# Altium schematic sheet GasCap.I2CSensors.SchDoc
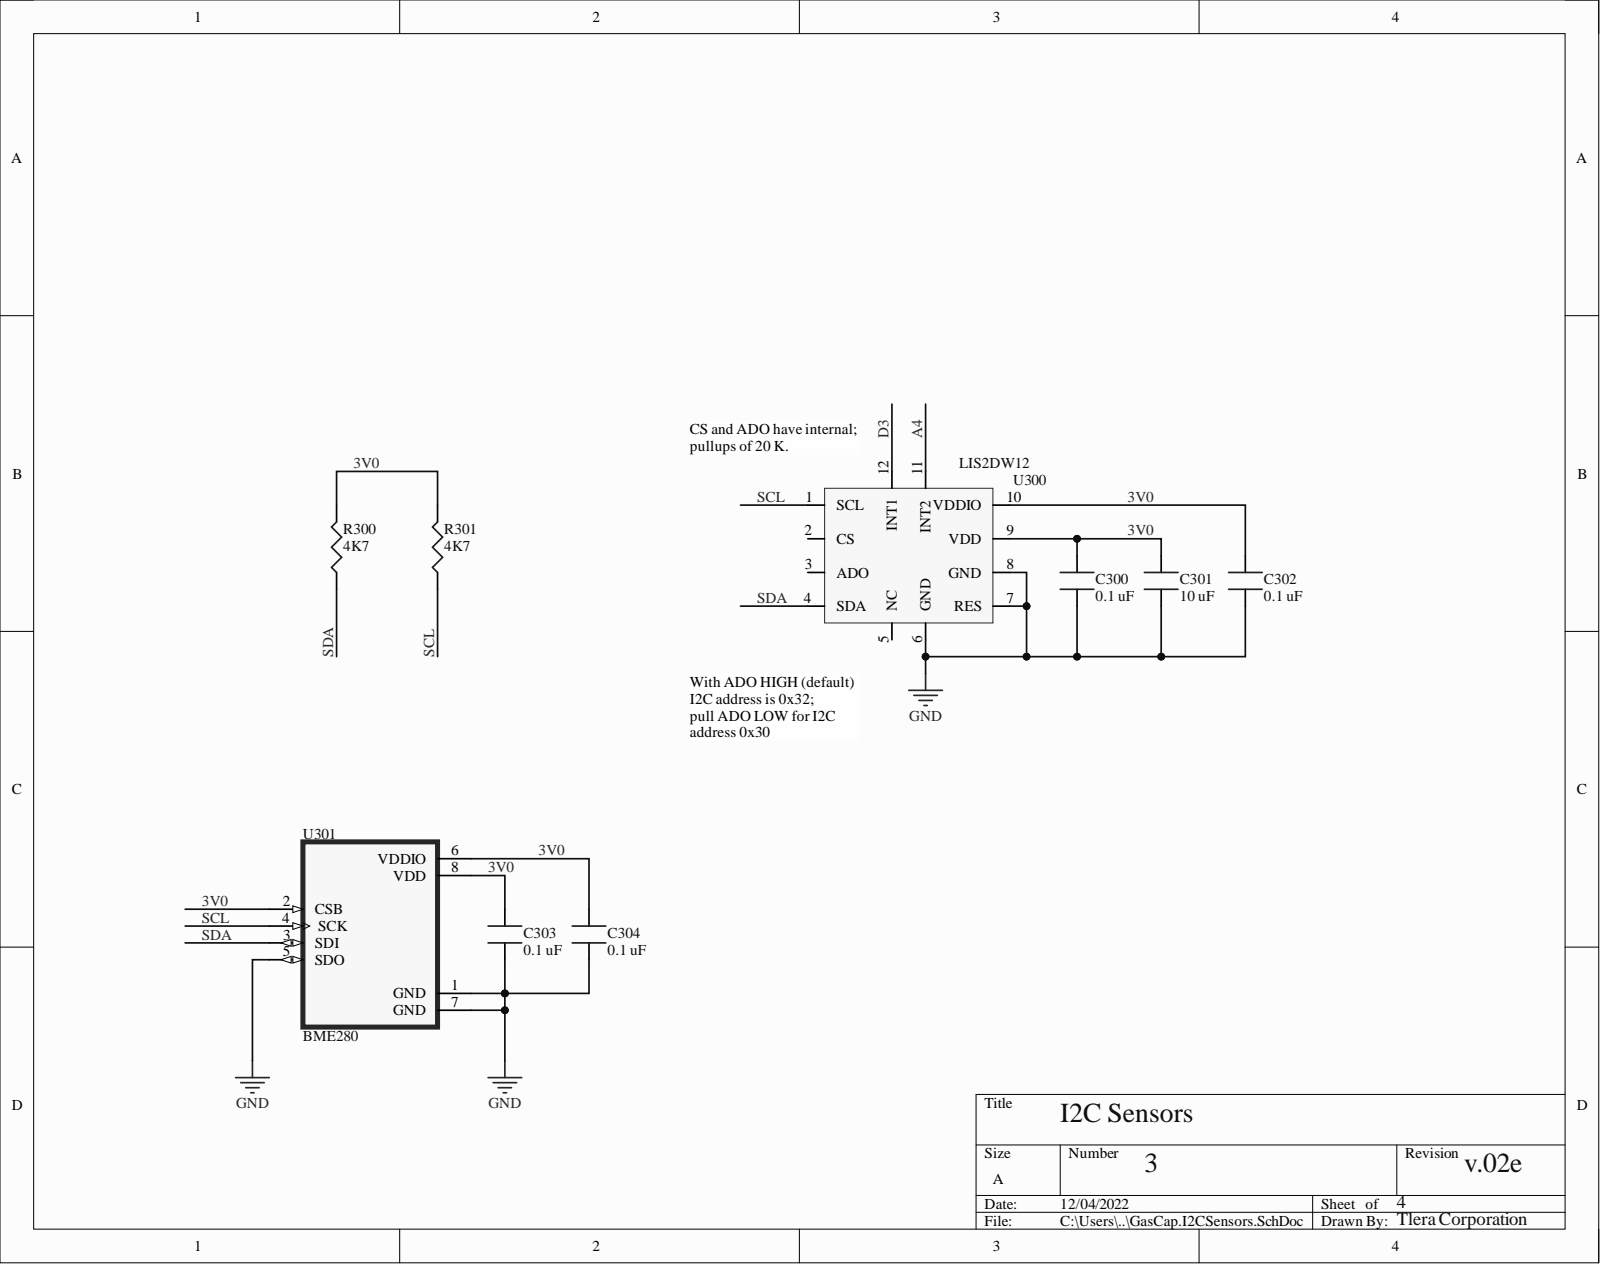
[diagram p0: GasCap.I2CSensors.SchDoc]

## Parts
C300  0.1 uF  [402Cap]
C301  10 uF  [402Cap]
C302  0.1 uF  [402Cap]
C303  0.1 uF  [402Cap]
C304  0.1 uF  [402Cap]
R300  4K7  [402RES]
R301  4K7  [402RES]
U300  LIS2DW12  [LIS2DW12]
U301  BME280  [PSON65P250X250X100-8N]

## Nets
GND: C300.1 C301.1 C302.1 C303.1 C304.1 U300.6(GND) U300.7(RES) U300.8(GND) U301.1(GND) U301.5(SDO) U301.7(GND)
3V0: C300.2 C301.2 C302.2 C303.2 C304.2 R300.2 R301.2 U300.9(VDD) U300.10(VDDIO) U301.2(CSB) U301.6(VDDIO) U301.8(VDD)
A4: U300.11(INT2)
D3: U300.12(INT1)
SCL: R301.1 U300.1(SCL) U301.4(SCK)
SDA: R300.1 U300.4(SDA) U301.3(SDI)

Not connected: U300 2(CS) 3(ADO) 5(NC)

## Library data of the parts
C300 C302-C304 = CL05B104KO5NNNC: 0402 0.1 uF Ceramic Capacitor, Multilayer, Ceramic, 16V, 10% +Tol, 10% -Tol, X7R, 15% TC, 0.1uF, Surface Mount, 0402; Manufacturer: Samsung; Capacitance: 100nF; Multilayer: Yes; Capacitor Type: Ceramic; Category: Ceramic Capacitors; Component Kind: Standard; ComponentLink1Description: https://datasheet.ciiva.com:443/pdfs/VipMasterIC/IC/SEMS/SEMS-S-A0008384515/SEMS-S-A0008872921-1.pdf?src-supplier=IHS+Markit; ComponentLink1URL: https://datasheet.ciiva.com:443/pdfs/VipMasterIC/IC/SEMS/SEMS-S-A0008384515/SEMS-S-A0008872921-1.pdf?src-supplier=IHS+Markit; ComponentLink2Description: https://datasheet.ciiva.com/26920/getdatasheetpartid-359683-26920169.pdf?src-supplier=Verical; ComponentLink2URL: https://datasheet.ciiva.com/26920/getdatasheetpartid-359683-26920169.pdf?src-supplier=Verical; ComponentLink3Description: https://datasheet.ciiva.com/29198/458718-da-01-en-vs-kondensator-0402-29198423.pdf?src-supplier=Conrad; ComponentLink3URL: https://datasheet.ciiva.com/29198/458718-da-01-en-vs-kondensator-0402-29198423.pdf?src-supplier=Conrad; ComponentLink4Description: https://datasheet.ciiva.com/16208/cl05b104kq5nnnc-16208343.pdf?src-supplier=Future+Electronics; ComponentLink4URL: https://datasheet.ciiva.com/16208/cl05b104kq5nnnc-16208343.pdf?src-supplier=Future+Electronics; ComponentLink5Description: https://datasheet.ciiva.com/26309/mlcc-catalogdownload2013-eng-26309003.pdf?src-supplier=Distrelec; ComponentLink5URL: https://datasheet.ciiva.com/26309/mlcc-catalogdownload2013-eng-26309003.pdf?src-supplier=Distrelec; Dielectric Material: Ceramic; Height: 0.55mm; JESD-609 Code: e3; Length: 1mm; Manufacturer Part Number: CL05B104KO5NNNC; Max Operating Temperature: 125°C; Min Operating Temperature: -55°C; Mount: Surface Mount; Negative Tolerance: 10%; Number of Pins: 2; Package Shape: Rectangular; Package Style: SMTMeter; Packaging: Tape and Reel; Positive Tolerance: 10%; Pricing 1: 1=0.10000, 10=0.02600, 50=0.01400, 100=0.01150, 500=0.00820, 1000=0.00644, 2500=0.00586, 5000=0.00539 (USD); Rated DC Voltage (URdc): 16V; REACH SVHC: Yes; RoHS: Yes; RoHS Compliant: Yes; Size Code: 0402; Stock 1: 30141598; Supplier 1: Digi-Key; Supplier Part Number 1: 1276-1001-1-ND; Temperature Characteristics Code: X7R; Terminal Finish: Nickel; Termination: Wraparound; Width: 0.5mm
  C300 C302: Library Name: Gnat.SchLib
  C303 C304: Library Name: GasCap.SchLib
C301 = CL05A106MQ5NUNC: 0402 10uF Ceramic Capacitor, Multilayer, Ceramic, 6.3V, 20% +Tol, 20% -Tol, X5R, 15% TC, 10uF, Surface Mount, 0402; Manufacturer: Samsung; Multilayer: Yes; Capacitance: 10uF; Capacitor Type: Ceramic; Category: Ceramic Capacitors; Component Kind: Standard; ComponentLink1Description: https://datasheet.ciiva.com:443/pdfs/VipMasterIC/IC/SEMS/SEMS-S-A0008521219/SEMS-S-A0008521300-1.pdf?src-supplier=IHS+Markit; ComponentLink1URL: https://datasheet.ciiva.com:443/pdfs/VipMasterIC/IC/SEMS/SEMS-S-A0008521219/SEMS-S-A0008521300-1.pdf?src-supplier=IHS+Markit; ComponentLink2Description: https://datasheet.ciiva.com/16437/cl05a106mq5nunc-16437356.pdf?src-supplier=Future+Electronics; ComponentLink2URL: https://datasheet.ciiva.com/16437/cl05a106mq5nunc-16437356.pdf?src-supplier=Future+Electronics; ComponentLink3Description: https://datasheet.ciiva.com/26877/getdatasheetpartid-355289-26877841.pdf?src-supplier=Verical; ComponentLink3URL: https://datasheet.ciiva.com/26877/getdatasheetpartid-355289-26877841.pdf?src-supplier=Verical; Dielectric Material: Ceramic; Height: 0.7mm; JESD-609 Code: e3; Length: 1mm; Manufacturer Part Number: CL05A106MQ5NUNC; Max Operating Temperature: 85°C; Min Operating Temperature: -55°C; Mount: Surface Mount; Negative Tolerance: 20%; Number of Pins: 2; Package Shape: Rectangular; Package Style: SMTMeter; Packaging: Tape and Reel; Positive Tolerance: 20%; Pricing 1: 1=0.26000, 10=0.17600, 50=0.10540, 100=0.08900, 500=0.06086, 1000=0.05384, 2500=0.05150, 5000=0.04682 (USD); Rated DC Voltage (URdc): 6.3V; REACH SVHC: Yes; RoHS: Yes; RoHS Compliant: Yes; Size Code: 0402; Stock 1: 9272723; Supplier 1: Digi-Key; Supplier Part Number 1: 1276-1451-1-ND; Temperature Characteristics Code: X5R; Terminal Finish: Nickel; Termination: Wraparound; Width: 0.5mm; Library Name: Gnat.SchLib
R300 R301 = RC0402FR-074K7P: 0402 4K7 Fixed Resistor, Metal Glaze/thick Film, 0.0625W, 4700ohm, 50V, 1% +/-Tol, 150ppm/Cel, Surface Mount, 0402; Manufacturer: Yageo; Additional Feature: LASER TRIMMABLE; Rated Power Dissipation (P): 62.5mW; Rated Temperature: 70°C; Resistance: 4.7kR; Temperature Coefficient: 150ppm/°C; Category: Chip SMD Resistors; Component Kind: Standard; ComponentLink1Description: https://datasheet.ciiva.com:443/pdfs/VipMasterIC/IC/YAGO/YAGO-S-A0006248068/YAGO-S-A0006248068-1.pdf?src-supplier=IHS+Markit; ComponentLink1URL: https://datasheet.ciiva.com:443/pdfs/VipMasterIC/IC/YAGO/YAGO-S-A0006248068/YAGO-S-A0006248068-1.pdf?src-supplier=IHS+Markit; ComponentLink2Description: http://www.yageo.com.tw/exep/pages/download/literatures/PYu-R_INT-thick_7.pdf?src-supplier=Digi-Key; ComponentLink2URL: http://www.yageo.com.tw/exep/pages/download/literatures/PYu-R_INT-thick_7.pdf?src-supplier=Digi-Key; ComponentLink3Description: http://www.yageo.com/NewPortal/yageodocoutput?fileName=/pdf/R-Chip/PYu-RC_51_RoHS_P_0.pdf&src-supplier=Digi-Key; ComponentLink3URL: http://www.yageo.com/NewPortal/yageodocoutput?fileName=/pdf/R-Chip/PYu-RC_51_RoHS_P_0.pdf&src-supplier=Digi-Key; JESD-609 Code: e3; Manufacturer Part Number: RC0402FR-074K7P; Max Operating Temperature: 155°C; Min Operating Temperature: -55°C; Mount: Surface Mount; Number of Pins: 2; Package Shape: Rectangular; Packaging: Tape and Reel; Pricing 1: 1=0.10000, 10=0.03400, 100=0.01390, 1000=0.00624, 2500=0.00542, 5000=0.00447 (USD); REACH SVHC: Yes; Resistor Type: FIXED RESISTOR; RoHS: Yes; RoHS Compliant: Yes; Size Code: 0402; Stock 1: 294510; Supplier 1: Digi-Key; Supplier Part Number 1: YAG1272CT-ND; Technology: METAL GLAZE/THICK FILM; Terminal Finish: Nickel; Termination: Wraparound; Tolerance: 1%; Working Voltage: 50V; Library Name: Gnat.SchLib
U300 = LIS2DW12: Accelerometer X, Y, Z Axis ±2g, 4g, 8g, 16g 400Hz 12-LGA (2x2); Manufacturer: STMicroelectronics; Manufacturer Part Number: LIS2DW12TR; Analog IC - Other Type: ANALOG CIRCUIT; Number of Functions: 1; Supply Voltage-Max (Vsup): 3.6V; Supply Voltage-Min (Vsup): 1.62V; Supply Voltage-Nom (Vsup): 1.8V; Category: Accelerometers; Component Kind: Standard; ComponentLink1Description: https://datasheet.ciiva.com:443/pdfs/VipMasterIC/IC/SGST/SGST-S-A0008492611/SGST-S-A0008492611-1.pdf?src-supplier=IHS+Markit; ComponentLink1URL: https://datasheet.ciiva.com:443/pdfs/VipMasterIC/IC/SGST/SGST-S-A0008492611/SGST-S-A0008492611-1.pdf?src-supplier=IHS+Markit; ComponentLink2Description: http://www.farnell.com/datasheets/2581666.pdf?src-supplier=Farnell; ComponentLink2URL: http://www.farnell.com/datasheets/2581666.pdf?src-supplier=Farnell; ComponentLink3Description: http://www.st.com/content/ccc/resource/technical/document/datasheet/group3/30/3a/4e/6b/68/16/4a/35/DM00323179/files/DM00323179.pdf/jcr:content/translations/en.DM00323179.pdf?src-supplier=Digi-Key; ComponentLink3URL: http://www.st.com/content/ccc/resource/technical/document/datasheet/group3/30/3a/4e/6b/68/16/4a/35/DM00323179/files/DM00323179.pdf/jcr:content/translations/en.DM00323179.pdf?src-supplier=Digi-Key; ComponentLink4Description: http://www.farnell.com/datasheets/2581666.pdf?src-supplier=Newark; ComponentLink4URL: http://www.farnell.com/datasheets/2581666.pdf?src-supplier=Newark; Height - Seated (Max): 0.7mm; Length: 2mm; Max Operating Temperature: 85°C; Min Operating Temperature: -40°C; Number of Pins: 12; Package Code: VFLGA; Package Shape: Square; Package Style: GRID ARRAY, VERY THIN PROFILE, FINE PITCHMeter; Pricing 1: 1=4.33000, 10=3.91000, 25=3.69000, 50=3.41000, 100=3.13000, 250=2.97000, 500=2.58000, 1000=2.25000 (USD); REACH SVHC: Yes; RoHS: Yes; RoHS Compliant: Yes; Stock 1: 1827; Supplier 1: Newark; Supplier Part Number 1: 51AC9121; Surface Mount: Yes; Temperature Grade: INDUSTRIAL; Terminal Form: BUTT; Terminal Pitch: 0.5mm; Terminal Position: BOTTOM; Width: 2mm; Library Name: Gnat.SchLib
U301 = BME280: SENSOR PRESSURE HUMIDITY TEMP; Manufacturer: Bosch; Availability: Unavailable; Category: Temperature and Humidity Sensors; Component Kind: Standard; ComponentLink1Description: https://ae-bst.resource.bosch.com/media/_tech/media/product_flyer/BME280_Productflyer_BST_20170109.pdf?src-supplier=Mouser; ComponentLink1URL: https://ae-bst.resource.bosch.com/media/_tech/media/product_flyer/BME280_Productflyer_BST_20170109.pdf?src-supplier=Mouser; ComponentLink2Description: https://ae-bst.resource.bosch.com/media/_tech/media/datasheets/BST-BME280-DS002.pdf?src-supplier=Digi-Key; ComponentLink2URL: https://ae-bst.resource.bosch.com/media/_tech/media/datasheets/BST-BME280-DS002.pdf?src-supplier=Digi-Key; ComponentLink3Description: https://ae-bst.resource.bosch.com/media/_tech/media/product_flyer/BME280_Productflyer_BST_20170109.pdf?src-supplier=Arrow+Electronics; ComponentLink3URL: https://ae-bst.resource.bosch.com/media/_tech/media/product_flyer/BME280_Productflyer_BST_20170109.pdf?src-supplier=Arrow+Electronics; ComponentLink4Description: https://ae-bst.resource.bosch.com/media/_tech/media/product_flyer/BME280_Productflyer_BST_20170109.pdf?src-supplier=NAC+Semi; ComponentLink4URL: https://ae-bst.resource.bosch.com/media/_tech/media/product_flyer/BME280_Productflyer_BST_20170109.pdf?src-supplier=NAC+Semi; Manufacturer Part Number: BME280; MP: BME280; Package: None; Price: None; Pricing 1: 1=5.37000, 20=4.88000, 150=4.55000, 1000=4.24000, 5000=3.97000 (USD); RoHS: No; Stock 1: 3877; Supplier 1: Future Electronics; Supplier Part Number 1: 2053946; Library Name: GasCap.SchLib
